# Altium schematic sheet Rikky-board_v0r0_CONN.SchDoc
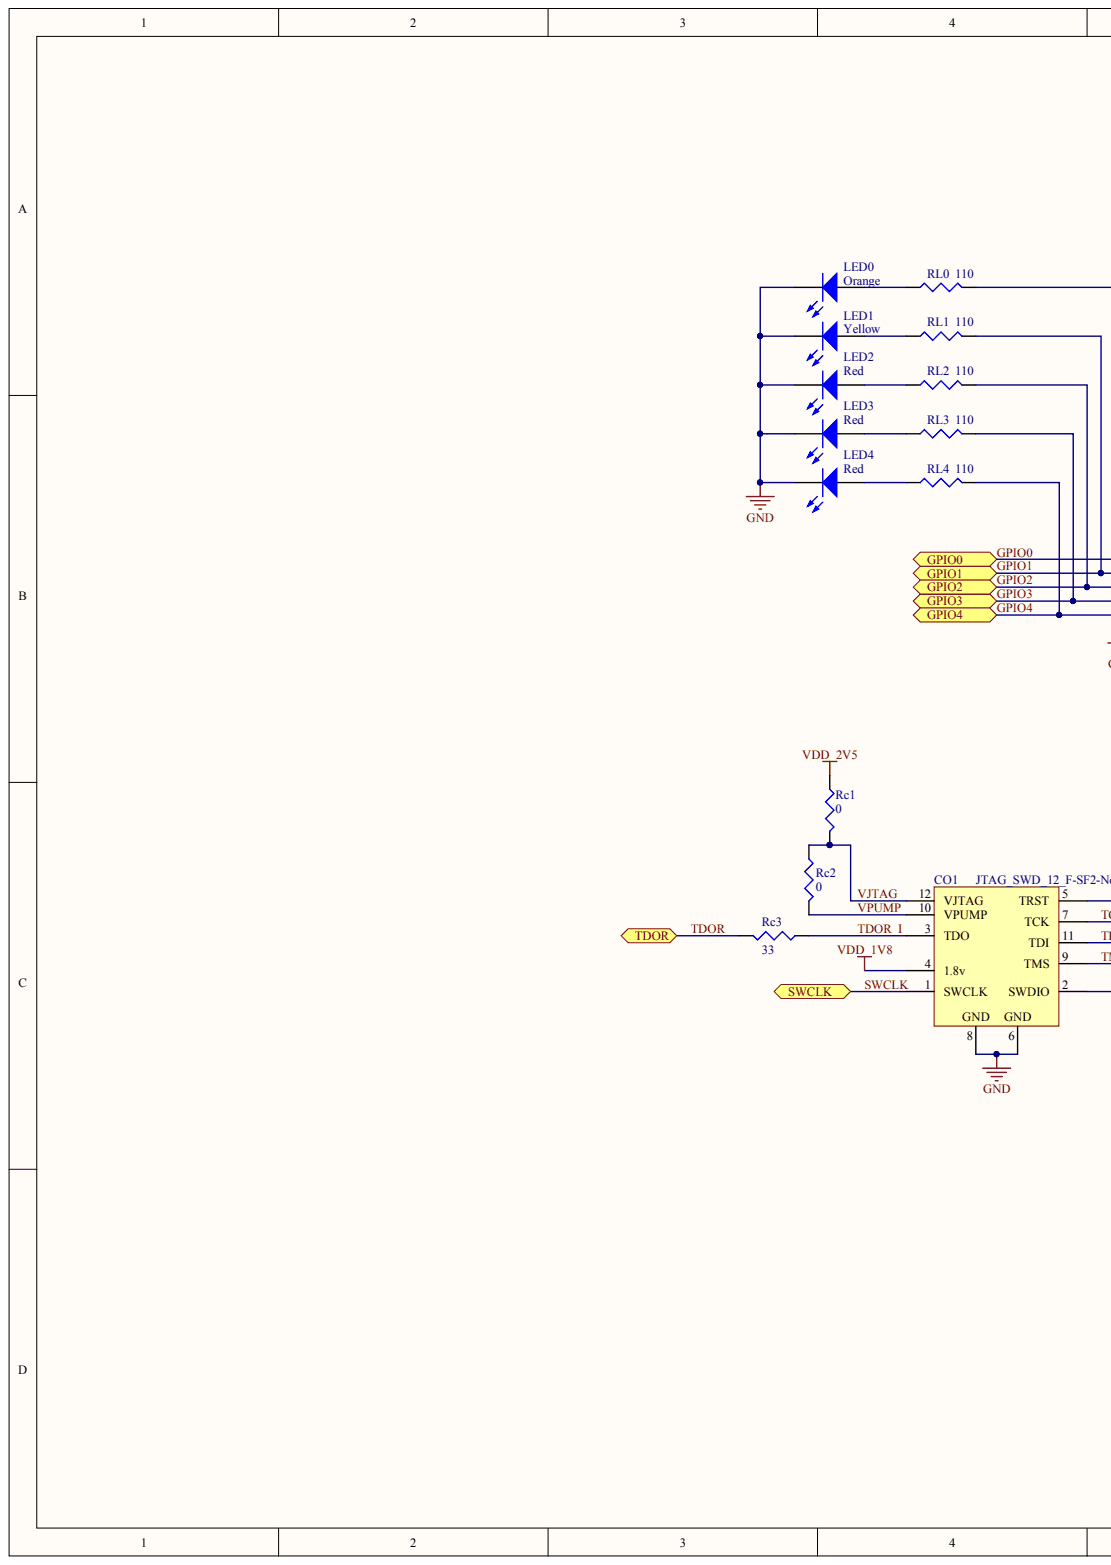
[diagram p0: Rikky-board_v0r0_CONN.SchDoc, left]
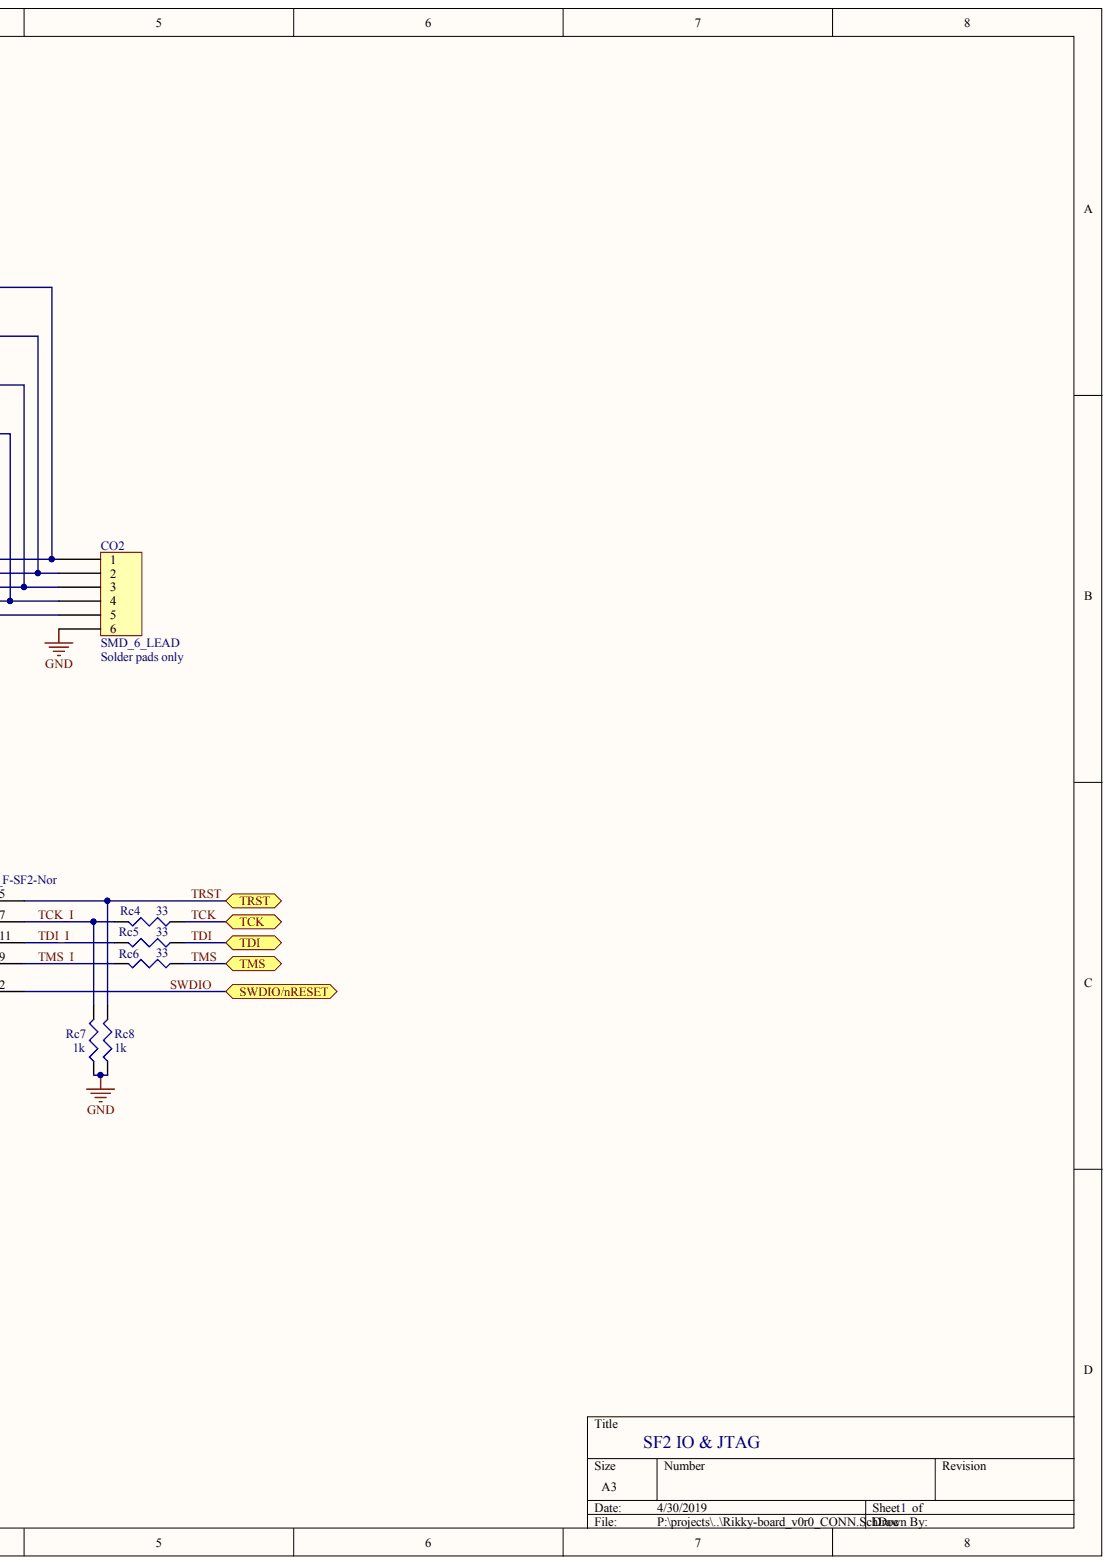
[diagram p1: Rikky-board_v0r0_CONN.SchDoc, right]

## Parts
CO1  JTAG_SWD_12_F-SF2-Nor
CO2  SMD_6_LEAD  Note: Solder pads only
LED0  Color: Orange
LED1  Color: Yellow
LED2  Color: Red
LED3  Color: Red
LED4  Color: Red
Rc1  value 0  (hidden comment: ERJ-2GE0R00X)
Rc2  value 0  (hidden comment: ERJ-2GE0R00X)
Rc3  value 33  (hidden comment: ERJ-2RKF33R0X)
Rc4  value 33  (hidden comment: ERJ-2RKF33R0X)
Rc5  value 33  (hidden comment: ERJ-2RKF33R0X)
Rc6  value 33  (hidden comment: ERJ-2RKF33R0X)
Rc7  value 1k  (hidden comment: ERJ-2RKF1001X)
Rc8  value 1k  (hidden comment: ERJ-2RKF1001X)
RL0  value 110  (hidden comment: ERJ-2RKF1100X)
RL1  value 110  (hidden comment: ERJ-2RKF1100X)
RL2  value 110  (hidden comment: ERJ-2RKF1100X)
RL3  value 110  (hidden comment: ERJ-2RKF1100X)
RL4  value 110  (hidden comment: ERJ-2RKF1100X)

## Ports
GPIO0, GPIO1, GPIO2, GPIO3, GPIO4, SWCLK, SWDIO/NRESET, TCK, TDI, TDOR, TMS, TRST

## Nets
GND: CO1.6 CO1.8 CO2.6 LED0.2 LED1.2 LED2.2 LED3.2 LED4.2 Rc7.1 Rc8.1
VDD_1V8: CO1.4(1.8v)
VDD_2V5: Rc1.1
GPIO0: CO2.1 RL0.2 ; port GPIO0
GPIO1: CO2.2 RL1.2 ; port GPIO1
GPIO2: CO2.3 RL2.2 ; port GPIO2
GPIO3: CO2.4 RL3.2 ; port GPIO3
GPIO4: CO2.5 RL4.2 ; port GPIO4
SWCLK: CO1.1(SWCLK) ; port SWCLK
SWDIO: CO1.2(SWDIO) ; port SWDIO/NRESET
TCK: Rc4.1 ; port TCK
TCK_I: CO1.7(TCK) Rc4.2 Rc7.2
TDI: Rc5.1 ; port TDI
TDI_I: CO1.11(TDI) Rc5.2
TDOR: Rc3.2 ; port TDOR
TDOR_I: CO1.3(TDO) Rc3.1
TMS: Rc6.1 ; port TMS
TMS_I: CO1.9(TMS) Rc6.2
TRST: CO1.5(TRST) Rc8.2 ; port TRST
VJTAG: CO1.12(VJTAG) Rc1.2 Rc2.1
VPUMP: CO1.10(VPUMP) Rc2.2
NetLED0_1: LED0.1 RL0.1
NetLED1_1: LED1.1 RL1.1
NetLED2_1: LED2.1 RL2.1
NetLED3_1: LED3.1 RL3.1
NetLED4_1: LED4.1 RL4.1

## Library data of the parts
CO1 = (no symbol name): Manufacturer: Samtec; Manufacturer PN: CLP-106-02-x-D; Supplier: Digikey; Supplier PN: SAM1153-06-ND
LED0 = (no symbol name): Manufacturer: Rohm; Manufacturer PN: SML-311DTT86; Supplier: Digikey; Supplier PN: 511-1303-1-ND; Comment: ="Manufactrurer PN"
LED1 = (no symbol name): Manufacturer: Rohm; Manufacturer PN: SML-311YTT86; Supplier: Digikey; Supplier PN: 511-1305-1-ND; Comment: ="Manufactrurer PN"
Rc1-Rc8 RL0-RL4 = (no symbol name): Manufacturer: Panasonic; Power: 1/10W; Supplier: Digikey; Package: 0402
  Rc1 Rc2: Manufacturer PN: ERJ-2GE0R00X; Supplier P/N: P0.0JCT-ND
  Rc3-Rc6: Manufacturer PN: ERJ-2RKF33R0X; Supplier P/N: P33.0LCT-ND
  Rc7 Rc8: Manufacturer PN: ERJ-2RKF1001X; Supplier P/N: P1.00KLCT-ND
  RL0-RL4: Manufacturer PN: ERJ-2RKF1100X; Supplier P/N: P110LCT-ND
CO2 LED2-LED4 = (no symbol name)
  LED2-LED4: Supplier: Digikey; Comment: ="Manufactrurer PN"
